# Altium schematic sheet EDA扩展板V4.0.SchDoc
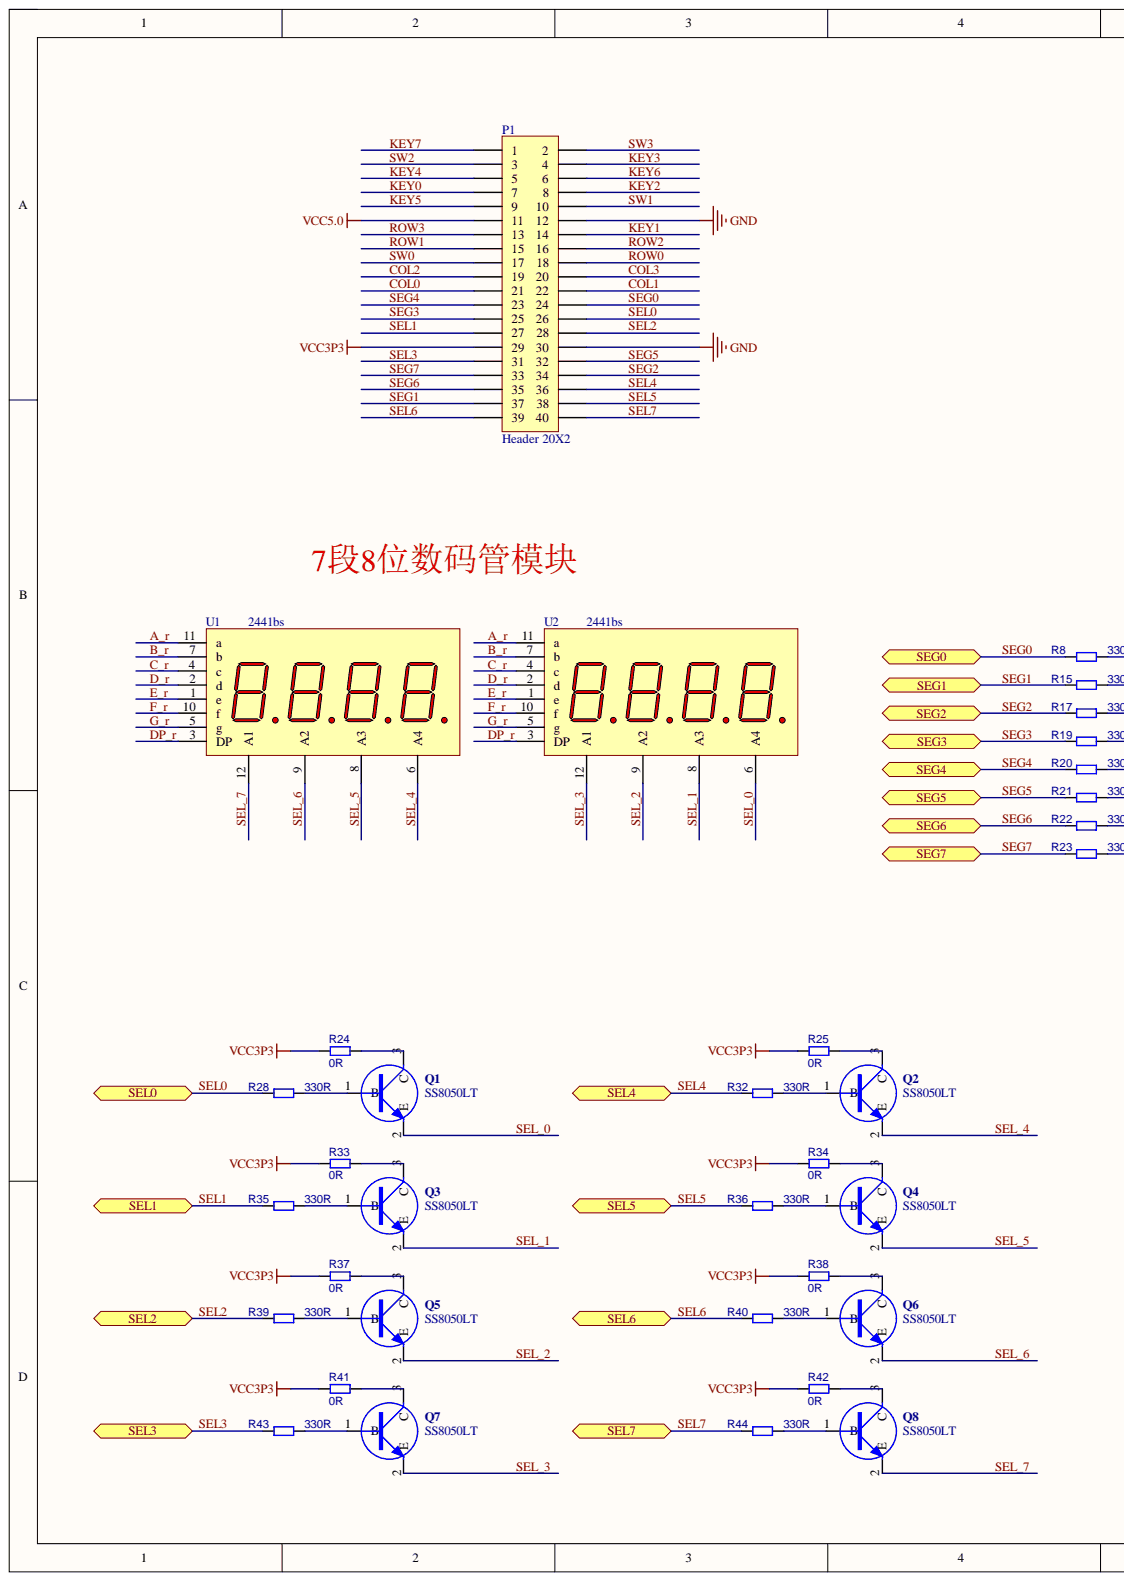
[diagram p0: EDA扩展板V4.0.SchDoc, left]
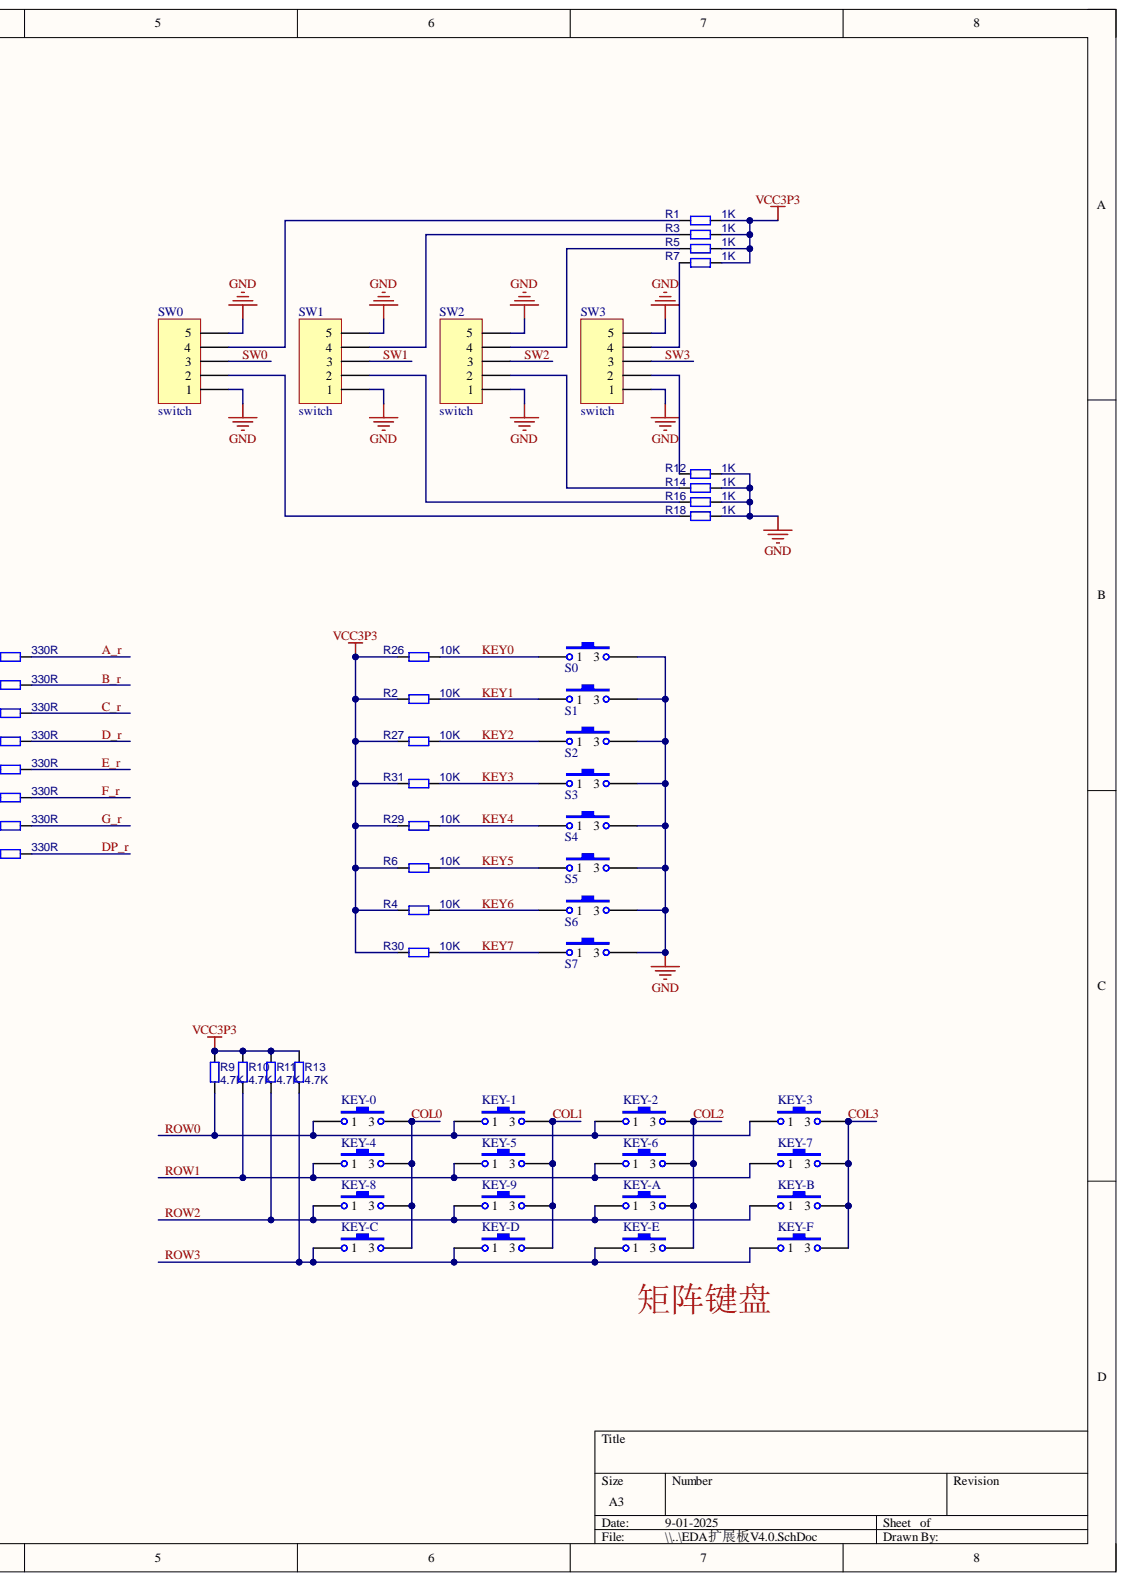
[diagram p1: EDA扩展板V4.0.SchDoc, right]

## Parts
KEY-0  轻触开关  [SW-SMD_4P-L4_2-W3_3-P2_15]  (value not drawn)
KEY-1  轻触开关  [SW-SMD_4P-L4_2-W3_3-P2_15]  (value not drawn)
KEY-2  轻触开关  [SW-SMD_4P-L4_2-W3_3-P2_15]  (value not drawn)
KEY-3  轻触开关  [SW-SMD_4P-L4_2-W3_3-P2_15]  (value not drawn)
KEY-4  轻触开关  [SW-SMD_4P-L4_2-W3_3-P2_15]  (value not drawn)
KEY-5  轻触开关  [SW-SMD_4P-L4_2-W3_3-P2_15]  (value not drawn)
KEY-6  轻触开关  [SW-SMD_4P-L4_2-W3_3-P2_15]  (value not drawn)
KEY-7  轻触开关  [SW-SMD_4P-L4_2-W3_3-P2_15]  (value not drawn)
KEY-8  轻触开关  [SW-SMD_4P-L4_2-W3_3-P2_15]  (value not drawn)
KEY-9  轻触开关  [SW-SMD_4P-L4_2-W3_3-P2_15]  (value not drawn)
KEY-A  轻触开关  [SW-SMD_4P-L4_2-W3_3-P2_15]  (value not drawn)
KEY-B  轻触开关  [SW-SMD_4P-L4_2-W3_3-P2_15]  (value not drawn)
KEY-C  轻触开关  [SW-SMD_4P-L4_2-W3_3-P2_15]  (value not drawn)
KEY-D  轻触开关  [SW-SMD_4P-L4_2-W3_3-P2_15]  (value not drawn)
KEY-E  轻触开关  [SW-SMD_4P-L4_2-W3_3-P2_15]  (value not drawn)
KEY-F  轻触开关  [SW-SMD_4P-L4_2-W3_3-P2_15]  (value not drawn)
P1  Header 20X2  [HDR2X20]
Q1  value SS8050LT  [SOT23]  (hidden comment: NPN-1)
Q2  value SS8050LT  [SOT23]  (hidden comment: NPN-1)
Q3  value SS8050LT  [SOT23]  (hidden comment: NPN-1)
Q4  value SS8050LT  [SOT23]  (hidden comment: NPN-1)
Q5  value SS8050LT  [SOT23]  (hidden comment: NPN-1)
Q6  value SS8050LT  [SOT23]  (hidden comment: NPN-1)
Q7  value SS8050LT  [SOT23]  (hidden comment: NPN-1)
Q8  value SS8050LT  [SOT23]  (hidden comment: NPN-1)
R1  1K  [0603R]
R2  10K  [0603R]
R3  1K  [0603R]
R4  10K  [0603R]
R5  1K  [0603R]
R6  10K  [0603R]
R7  1K  [0603R]
R8  330R  [0603R]
R9  4.7K  [0603R]
R10  4.7K  [0603R]
R11  4.7K  [0603R]
R12  1K  [0603R]
R13  4.7K  [0603R]
R14  1K  [0603R]
R15  330R  [0603R]
R16  1K  [0603R]
R17  330R  [0603R]
R18  1K  [0603R]
R19  330R  [0603R]
R20  330R  [0603R]
R21  330R  [0603R]
R22  330R  [0603R]
R23  330R  [0603R]
R24  0R  [0603R]
R25  0R  [0603R]
R26  10K  [0603R]
R27  10K  [0603R]
R28  330R  [0603R]
R29  10K  [0603R]
R30  10K  [0603R]
R31  10K  [0603R]
R32  330R  [0603R]
R33  0R  [0603R]
R34  0R  [0603R]
R35  330R  [0603R]
R36  330R  [0603R]
R37  0R  [0603R]
R38  0R  [0603R]
R39  330R  [0603R]
R40  330R  [0603R]
R41  0R  [0603R]
R42  0R  [0603R]
R43  330R  [0603R]
R44  330R  [0603R]
S0  轻触开关  [SW-SMD_4P-L4_2-W3_3-P2_15]  (value not drawn)
S1  轻触开关  [SW-SMD_4P-L4_2-W3_3-P2_15]  (value not drawn)
S2  轻触开关  [SW-SMD_4P-L4_2-W3_3-P2_15]  (value not drawn)
S3  轻触开关  [SW-SMD_4P-L4_2-W3_3-P2_15]  (value not drawn)
S4  轻触开关  [SW-SMD_4P-L4_2-W3_3-P2_15]  (value not drawn)
S5  轻触开关  [SW-SMD_4P-L4_2-W3_3-P2_15]  (value not drawn)
S6  轻触开关  [SW-SMD_4P-L4_2-W3_3-P2_15]  (value not drawn)
S7  轻触开关  [SW-SMD_4P-L4_2-W3_3-P2_15]  (value not drawn)
SW0  switch  [SW-1 X 2]
SW1  switch  [SW-1 X 2]
SW2  switch  [SW-1 X 2]
SW3  switch  [SW-1 X 2]
U1  2441bs  [LED-SEG-TH_SLR0224CR5C1BD-3.5]
U2  2441bs  [LED-SEG-TH_SLR0224CR5C1BD-3.5]

## Ports
SEG0, SEG1, SEG2, SEG3, SEG4, SEG5, SEG6, SEG7, SEL0, SEL1, SEL2, SEL3, SEL4, SEL5, SEL6, SEL7

## Nets
GND: P1.12 P1.30 R12.1 R14.1 R16.1 R18.1 S0.3 S1.3 S2.3 S3.3 S4.3 S5.3 S6.3 S7.3 SW0.1 SW0.5 SW1.1 SW1.5 SW2.1 SW2.5 SW3.1 SW3.5
VCC3P3: P1.29 R1.1 R2.2 R3.1 R4.2 R5.1 R6.2 R7.1 R9.1 R10.1 R11.1 R13.1 R24.1 R25.1 R26.2 R27.2 R29.2 R30.2 R31.2 R33.1 R34.1 R37.1 R38.1 R41.1 R42.1
VCC5.0: P1.11
A_r: R8.1 U1.11(a) U2.11(a)
B_r: R15.1 U1.7(b) U2.7(b)
C_r: R17.1 U1.4(c) U2.4(c)
COL0: KEY-0.3 KEY-4.3 KEY-8.3 KEY-C.3 P1.21
COL1: KEY-1.3 KEY-5.3 KEY-9.3 KEY-D.3 P1.22
COL2: KEY-2.3 KEY-6.3 KEY-A.3 KEY-E.3 P1.19
COL3: KEY-3.3 KEY-7.3 KEY-B.3 KEY-F.3 P1.20
D_r: R19.1 U1.2(d) U2.2(d)
DP_r: R23.1 U1.3(DP) U2.3(DP)
E_r: R20.1 U1.1(e) U2.1(e)
F_r: R21.1 U1.10(f) U2.10(f)
G_r: R22.1 U1.5(g) U2.5(g)
KEY0: P1.7 R26.1 S0.1
KEY1: P1.14 R2.1 S1.1
KEY2: P1.8 R27.1 S2.1
KEY3: P1.4 R31.1 S3.1
KEY4: P1.5 R29.1 S4.1
KEY5: P1.9 R6.1 S5.1
KEY6: P1.6 R4.1 S6.1
KEY7: P1.1 R30.1 S7.1
ROW0: KEY-0.1 KEY-1.1 KEY-2.1 KEY-3.1 P1.18 R9.2
ROW1: KEY-4.1 KEY-5.1 KEY-6.1 KEY-7.1 P1.15 R10.2
ROW2: KEY-8.1 KEY-9.1 KEY-A.1 KEY-B.1 P1.16 R11.2
ROW3: KEY-C.1 KEY-D.1 KEY-E.1 KEY-F.1 P1.13 R13.2
SEG0: P1.24 R8.2 ; port SEG0
SEG1: P1.37 R15.2 ; port SEG1
SEG2: P1.34 R17.2 ; port SEG2
SEG3: P1.25 R19.2 ; port SEG3
SEG4: P1.23 R20.2 ; port SEG4
SEG5: P1.32 R21.2 ; port SEG5
SEG6: P1.35 R22.2 ; port SEG6
SEG7: P1.33 R23.2 ; port SEG7
SEL0: P1.26 R28.2 ; port SEL0
SEL1: P1.27 R35.2 ; port SEL1
SEL2: P1.28 R39.2 ; port SEL2
SEL3: P1.31 R43.2 ; port SEL3
SEL4: P1.36 R32.2 ; port SEL4
SEL5: P1.38 R36.2 ; port SEL5
SEL6: P1.39 R40.2 ; port SEL6
SEL7: P1.40 R44.2 ; port SEL7
SEL_0: Q1.2 U2.6(A4)
SEL_1: Q3.2 U2.8(A3)
SEL_2: Q5.2 U2.9(A2)
SEL_3: Q7.2 U2.12(A1)
SEL_4: Q2.2 U1.6(A4)
SEL_5: Q4.2 U1.8(A3)
SEL_6: Q6.2 U1.9(A2)
SEL_7: Q8.2 U1.12(A1)
SW0: P1.17 SW0.3
SW1: P1.10 SW1.3
SW2: P1.3 SW2.3
SW3: P1.2 SW3.3
NetQ1_1: Q1.1 R28.1
NetQ1_3: Q1.3 R24.2
NetQ2_1: Q2.1 R32.1
NetQ2_3: Q2.3 R25.2
NetQ3_1: Q3.1 R35.1
NetQ3_3: Q3.3 R33.2
NetQ4_1: Q4.1 R36.1
NetQ4_3: Q4.3 R34.2
NetQ5_1: Q5.1 R39.1
NetQ5_3: Q5.3 R37.2
NetQ6_1: Q6.1 R40.1
NetQ6_3: Q6.3 R38.2
NetQ7_1: Q7.1 R43.1
NetQ7_3: Q7.3 R41.2
NetQ8_1: Q8.1 R44.1
NetQ8_3: Q8.3 R42.2
NetR1_2: R1.2 SW0.4
NetR3_2: R3.2 SW1.4
NetR5_2: R5.2 SW2.4
NetR7_2: R7.2 SW3.4
NetR12_2: R12.2 SW3.2
NetR14_2: R14.2 SW2.2
NetR16_2: R16.2 SW1.2
NetR18_2: R18.2 SW0.2

## Library data of the parts
KEY-0-KEY-9 KEY-A KEY-B KEY-C KEY-D KEY-E KEY-F S0-S7 = SW-PB: Switch; Manufacturer: Generic Components; Component Kind: Standard; Published: 1999; Library Name: xinluheng.SchLib
P1 = Header 20X2_1: IDC3-40，2.54mm，双排2*20简牛; Component Kind: Standard; LatestRevisionDate: 17-Jul-2002; LatestRevisionNote: Re-released for DXP Platform.; Library Name: zynq.SCHLIB; Signal Integrity: Connector; Publisher: Altium Limited
Q1-Q8 = 1.04.09.0001: SS8050LT(1.5A),SOT-23,江苏长电,RoHS; Database Table Name: VErpDataInfo; 名称: 三极管; 设备封装名称: SOT-23_SS8050LT; 贴片和直插: SMT; Component Kind: Standard; ComponentLink1Description: ErpDataInfo; ComponentLink1URL: http://erp.zlgmcu.com/ErpExt/ErpData/ListMateriel.aspx?pkey=1.04.09.0001; Library Name: ErpMaterialData.DbLib; RoHS: RoHS; 厂商: 江苏长电; 型号: SS8050LT(1.5A); 封装: SOT-23; 物料代码: 1.04.09.0001
R1-R44 = R: Value: DNI/4K7; Component Kind: Standard; Library Name: zynq.SCHLIB
SW0-SW3 = Header 5: Header, 5-Pin; Component Kind: Standard; LatestRevisionDate: 17-Jul-2002; LatestRevisionNote: Re-released for DXP Platform.; Library Name: EDA扩展板V2.SCHLIB; Publisher: Altium Limited
U1 U2 = 3641BH: 贴片和直插: SMT; Component Kind: Standard; Library Name: 数码管封装.SchLib
